# Altium schematic sheet ns.SchDoc(ns)
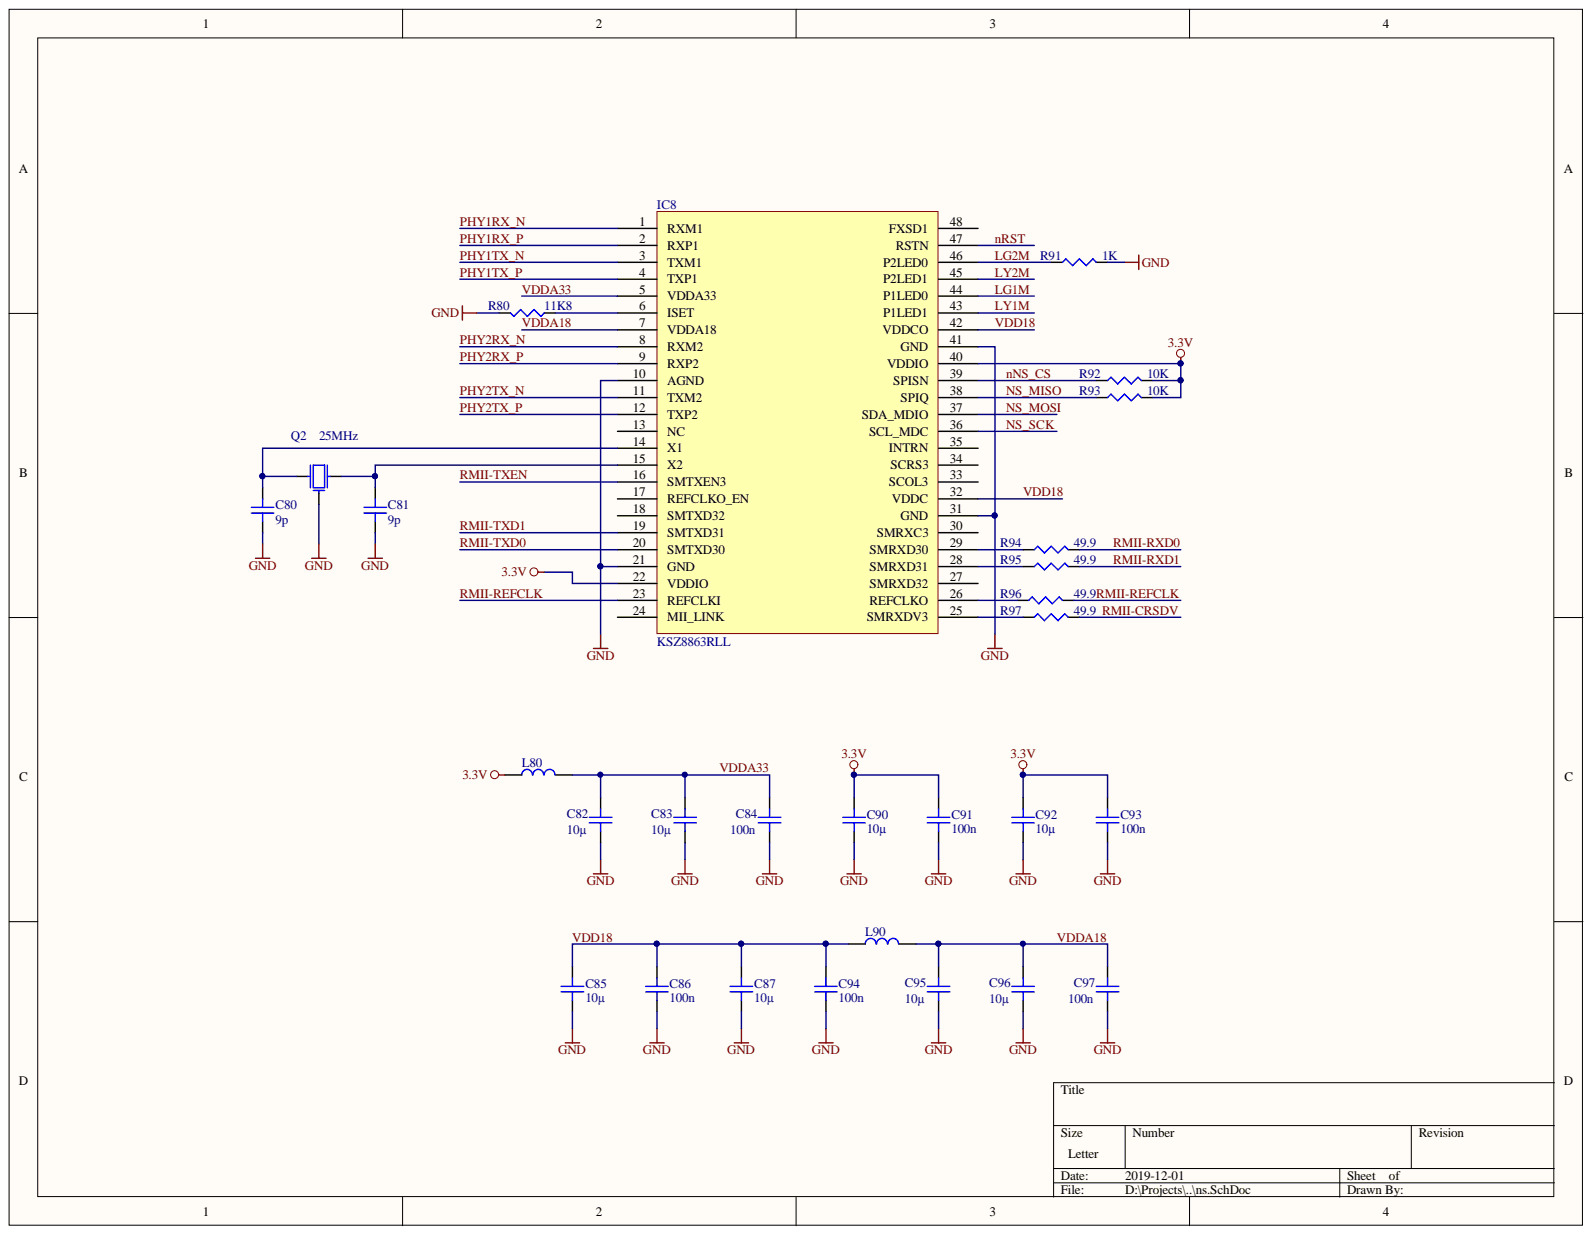
[diagram p0: ns.SchDoc]

## Parts
C80  9p  [0402_C]
C81  9p  [0402_C]
C82  10µ  [0603_C]
C83  10µ  [0603_C]
C84  100n  [0402_C]
C85  10µ  [0603_C]
C86  100n  [0402_C]
C87  10µ  [0603_C]
C90  10µ  [0603_C]
C91  100n  [0402_C]
C92  10µ  [0603_C]
C93  100n  [0402_C]
C94  100n  [0402_C]
C95  10µ  [0603_C]
C96  10µ  [0603_C]
C97  100n  [0402_C]
IC8  KSZ8863RLL  [TQFP48 7x7 P0.5]
L80  (no value)  [0402_R]
L90  (no value)  [0402_R]
Q2  25MHz  [Q-3225]
R80  11K8  [0603_R]
R91  1K  [0402_R]
R92  10K  [0402_R]
R93  10K  [0402_R]
R94  49.9  [0402_R]
R95  49.9  [0402_R]
R96  49.9  [0402_R]
R97  49.9  [0402_R]

## Nets
3.3V: C90.2 C91.2 C92.2 C93.2 IC8.22(VDDIO) IC8.40(VDDIO) L80.2 R92.2 R93.2
GND: C80.1 C81.1 C82.1 C83.1 C84.1 C85.1 C86.1 C87.1 C90.1 C91.1 C92.1 C93.1 C94.1 C95.1 C96.1 C97.1 IC8.10(AGND) IC8.21(GND) IC8.31(GND) IC8.41(GND) Q2.2 R80.2 R91.2
LG1M: IC8.44(P1LED0)
LG2M: IC8.46(P2LED0) R91.1
LY1M: IC8.43(P1LED1)
LY2M: IC8.45(P2LED1)
NNS_CS: IC8.39(SPISN) R92.1
NRST: IC8.47(RSTN)
NS_MISO: IC8.38(SPIQ) R93.1
NS_MOSI: IC8.37(SDA_MDIO)
NS_SCK: IC8.36(SCL_MDC)
PHY1RX_N: IC8.1(RXM1)
PHY1RX_P: IC8.2(RXP1)
PHY1TX_N: IC8.3(TXM1)
PHY1TX_P: IC8.4(TXP1)
PHY2RX_N: IC8.8(RXM2)
PHY2RX_P: IC8.9(RXP2)
PHY2TX_N: IC8.11(TXM2)
PHY2TX_P: IC8.12(TXP2)
RMII-CRSDV: R97.2
RMII-REFCLK: IC8.23(REFCLKI) R96.2
RMII-RXD0: R94.2
RMII-RXD1: R95.2
RMII-TXD0: IC8.20(SMTXD30)
RMII-TXD1: IC8.19(SMTXD31)
RMII-TXEN: IC8.16(SMTXEN3)
VDD18: C85.2 C86.2 C87.2 C94.2 IC8.32(VDDC) IC8.42(VDDCO) L90.2
VDDA18: C95.2 C96.2 C97.2 IC8.7(VDDA18) L90.1
VDDA33: C82.2 C83.2 C84.2 IC8.5(VDDA33) L80.1
NetC80_2: C80.2 IC8.14(X1) Q2.1
NetC81_2: C81.2 IC8.15(X2) Q2.3
NetIC8_6: IC8.6(ISET) R80.1
NetIC8_25: IC8.25(SMRXDV3) R97.1
NetIC8_26: IC8.26(REFCLKO) R96.1
NetIC8_28: IC8.28(SMRXD31) R95.1
NetIC8_29: IC8.29(SMRXD30) R94.1

Not connected: IC8 13(NC) 17(REFCLKO_EN) 18(SMTXD32) 24(MII_LINK) 27(SMRXD32) 30(SMRXC3) 33(SCOL3) 34(SCRS3) 35(INTRN) 48(FXSD1)

## Library data of the parts
C80 C81 = Cap: CAP CER 9PF 50V COG, NPO 0402; Manufacturer: YAGEO; Part Number: CC0402CRNPO9BN9R0; Component Kind: Standard; Library Name: SchLib.SchLib
C82 C83 C85 C87 C90 C92 C95 C96 = Cap: CAP CER 10UF 6.3V X5R 0603; Manufacturer: SAMSUNG E-M; Part Number: CL10A106MQ8NNNC; Component Kind: Standard; Library Name: SchLib.SchLib
C84 C86 C91 C93 C94 C97 = Cap: CAP CER 0.1UF 16V X7R 0402; Manufacturer: YAGEO; Part Number: CC0402KRX7R7BB104; Component Kind: Standard; Library Name: SchLib.SchLib
IC8 = KSZ8863: ETH 3PORT (R)MII 10/100 48TQFP; Manufacturer: MICROCHIP; Component Kind: Standard; Library Name: SchLib.SchLib; Part Number: KSZ8863RLL
L80 L90 = Inductor: FERRITE BEAD 120/55 OHM 1.1A 0402; Manufacturer: Murata; Part Number: BLM15PX121SN1D; Component Kind: Standard; Library Name: SchLib.SchLib
Q2 = Crystal4p: CRYSTAL 25MHZ 9PF SMT 3225; Manufacturer: EPSON; Part Number: X1E0000210621; Component Kind: Standard; Library Name: SchLib.SchLib
R80 = Res: RES 11.8K OHM 1% 0.1W 0603; Manufacturer: YAGEO; Part Number: RC0603FR-0711K8L; Component Kind: Standard; Library Name: SchLib.SchLib
R91 = Res: RES 1K OHM 1% 0.063W 0402; Manufacturer: YAGEO; Part Number: RC0402FR-071KL; Component Kind: Standard; Library Name: SchLib.SchLib
R92 R93 = Res: RES 10K OHM 1% 0.063W 0402; Manufacturer: YAGEO; Part Number: RC0402FR-0710KL; Component Kind: Standard; Library Name: SchLib.SchLib
R94-R97 = Res: RES 49.9 OHM 1% 0.063W 0402; Manufacturer: YAGEO; Part Number: RC0402FR-0749R9L; Component Kind: Standard; Library Name: SchLib.SchLib
